# Altium schematic sheet ECU_QGSM2.SchDoc
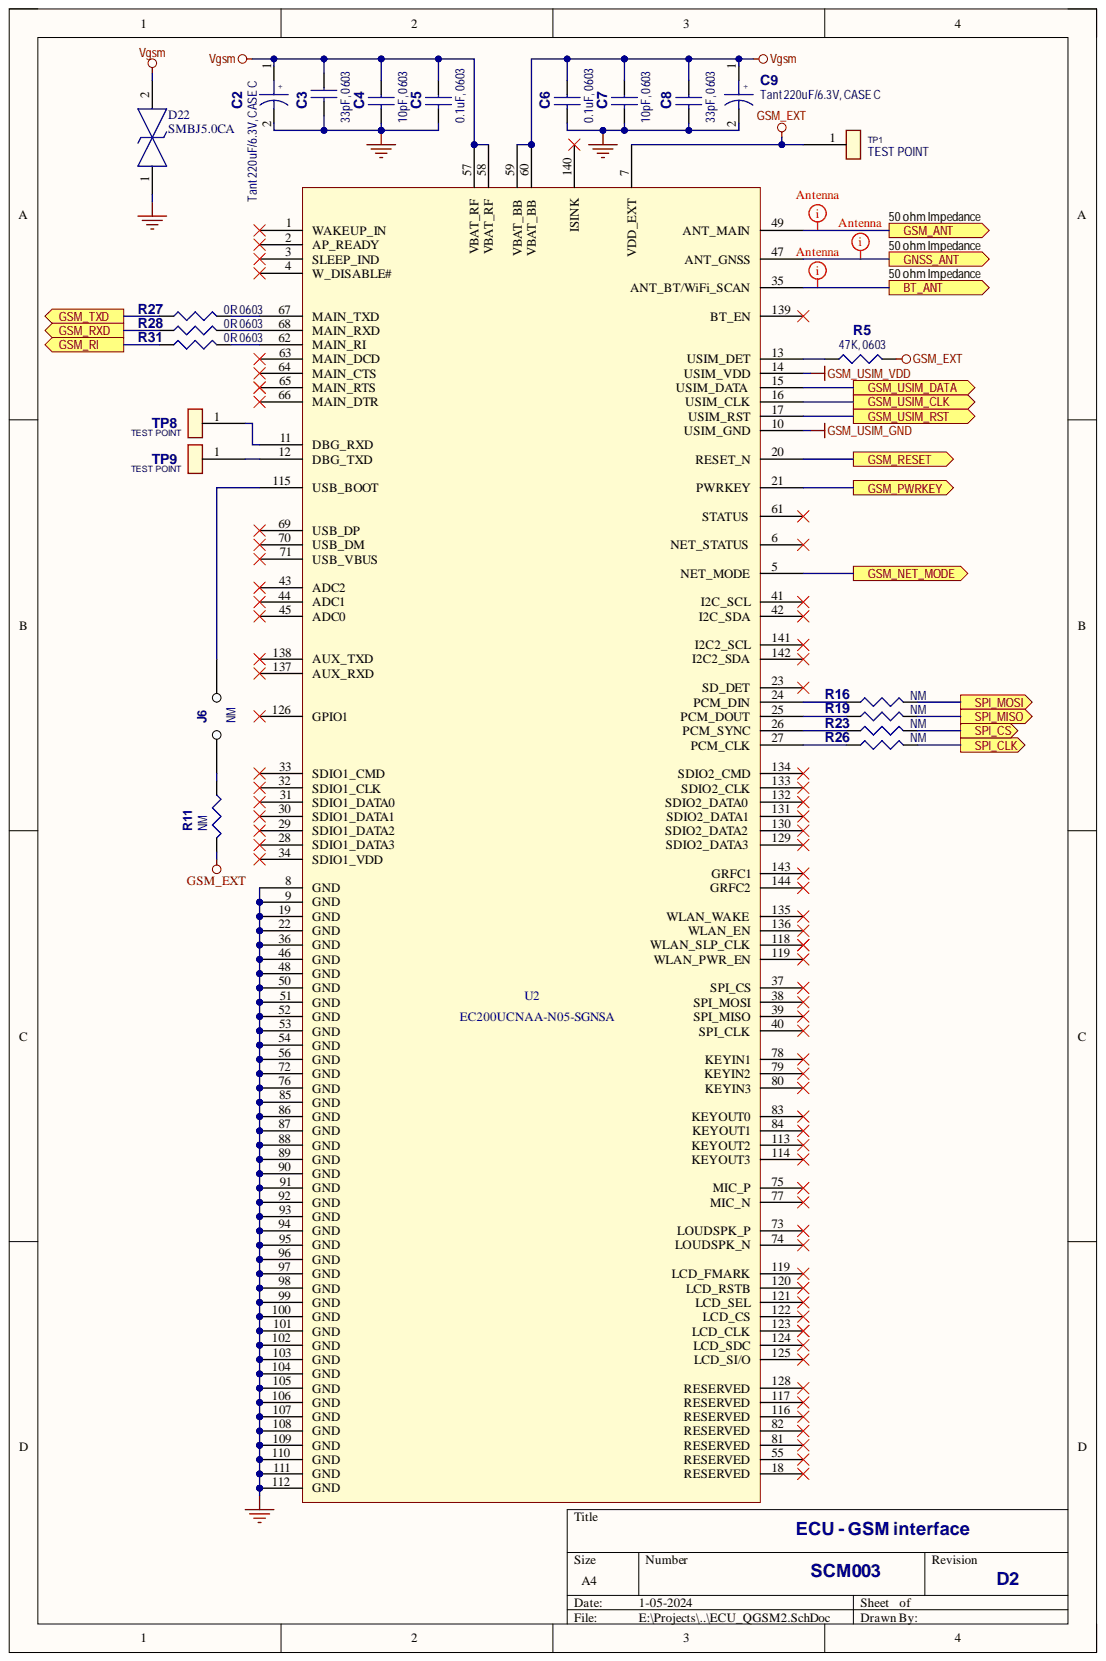
[diagram p0: ECU_QGSM2.SchDoc]

## Parts
C2  Tant 220uF/6.3V, CASE C  [220UF]
C3  33pF, 0603  [C0603]
C4  10pF, 0603  [C0603]
C5  0.1uF, 0603  [C0603]
C6  0.1uF, 0603  [C0603]
C7  10pF, 0603  [C0603]
C8  33pF, 0603  [C0603]
C9  Tant 220uF/6.3V, CASE C  [220UF]
D22  SMBJ5.0CA  [DIONM5436X244N]
J6  NM  [HDR1X2]
R5  47K, 0603  [R0603]
R11  NM  [R0603]
R16  NM  [R0603]
R19  NM  [R0603]
R23  NM  [R0603]
R26  NM  [R0603]
R27  0R 0603  [R0603]
R28  0R 0603  [R0603]
R31  0R 0603  [R0603]
TP1  TEST POINT  [Test Point SMD 1.5mm]
TP8  TEST POINT  [Test Point SMD 1.5mm]
TP9  TEST POINT  [Test Point SMD 1.5mm]
U2  EC200UCNAA-N05-SGNSA  [EC200U]

## Ports
BT_ANT, GNSS_ANT, GSM_ANT, GSM_NET_MODE, GSM_PWRKEY, GSM_RESET, GSM_RI, GSM_RXD, GSM_TXD, GSM_USIM_CLK, GSM_USIM_DATA, GSM_USIM_RST, SPI_CLK, SPI_CS, SPI_MISO, SPI_MOSI

## Nets
GND_POWER: C2.2 C3.2 C4.2 C5.2 C6.2 C7.2 C8.2 C9.2 D22.1 U2.8(GND) U2.9(GND) U2.19(GND) U2.22(GND) U2.36(GND) U2.46(GND) U2.48(GND) U2.50(GND) U2.51(GND) U2.52(GND) U2.53(GND) U2.54(GND) U2.56(GND) U2.72(GND) U2.76(GND) U2.85(GND) U2.86(GND) U2.87(GND) U2.88(GND) U2.89(GND) U2.90(GND) U2.91(GND) U2.92(GND) U2.93(GND) U2.94(GND) U2.95(GND) U2.96(GND) U2.97(GND) U2.98(GND) U2.99(GND) U2.100(GND) U2.101(GND) U2.102(GND) U2.103(GND) U2.104(GND) U2.105(GND) U2.106(GND) U2.107(GND) U2.108(GND) U2.109(GND) U2.110(GND) U2.111(GND) U2.112(GND)
GSM_EXT: R5.2 R11.1 TP1.1 U2.7(VDD_EXT)
GSM_USIM_GND: U2.10(USIM_GND)
GSM_USIM_VDD: U2.14(USIM_VDD)
Vgsm: C2.1 C3.1 C4.1 C5.1 C6.1 C7.1 C8.1 C9.1 D22.2 U2.57(VBAT_RF) U2.58(VBAT_RF) U2.59(VBAT_BB) U2.60(VBAT_BB)
BT_ANT: U2.35(ANT_BT/WiFi_SCAN) ; port BT_ANT
GNSS_ANT: U2.47(ANT_GNSS) ; port GNSS_ANT
GSM_ANT: U2.49(ANT_MAIN) ; port GSM_ANT
GSM_NET_MODE: U2.5(NET_MODE) ; port GSM_NET_MODE
GSM_PWRKEY: U2.21(PWRKEY) ; port GSM_PWRKEY
GSM_RESET: U2.20(RESET_N) ; port GSM_RESET
GSM_RI: R31.1 ; port GSM_RI
GSM_RXD: R28.1 ; port GSM_RXD
GSM_TXD: R27.1 ; port GSM_TXD
GSM_USIM_CLK: U2.16(USIM_CLK) ; port GSM_USIM_CLK
GSM_USIM_DATA: U2.15(USIM_DATA) ; port GSM_USIM_DATA
GSM_USIM_RST: U2.17(USIM_RST) ; port GSM_USIM_RST
SPI_CLK: R26.2 ; port SPI_CLK
SPI_CS: R23.2 ; port SPI_CS
SPI_MISO: R19.2 ; port SPI_MISO
SPI_MOSI: R16.2 ; port SPI_MOSI
NetJ6_1: J6.1 U2.115(USB_BOOT)
NetJ6_2: J6.2 R11.2
NetR5_1: R5.1 U2.13(USIM_DET)
NetR16_1: R16.1 U2.24(PCM_DIN)
NetR19_1: R19.1 U2.25(PCM_DOUT)
NetR23_1: R23.1 U2.26(PCM_SYNC)
NetR26_1: R26.1 U2.27(PCM_CLK)
NetR27_2: R27.2 U2.67(MAIN_TXD)
NetR28_2: R28.2 U2.68(MAIN_RXD)
NetR31_2: R31.2 U2.62(MAIN_RI)
NetTP8_1: TP8.1 U2.11(DBG_RXD)
NetTP9_1: TP9.1 U2.12(DBG_TXD)

Not connected: U2 1(WAKEUP_IN) 2(AP_READY) 3(SLEEP_IND) 4(W_DISABLE#) 6(NET_STATUS) 18(RESERVED) 23(SD_DET) 28(SDIO1_DATA3) 29(SDIO1_DATA2) 30(SDIO1_DATA1) 31(SDIO1_DATA0) 32(SDIO1_CLK) 33(SDIO1_CMD) 34(SDIO1_VDD) 37(SPI_CS) 38(SPI_MOSI) 39(SPI_MISO) 40(SPI_CLK) 41(I2C_SCL) 42(I2C_SDA) 43(ADC2) 44(ADC1) 45(ADC0) 55(RESERVED) 61(STATUS) 63(MAIN_DCD) 64(MAIN_CTS) 65(MAIN_RTS) 66(MAIN_DTR) 69(USB_DP) 70(USB_DM) 71(USB_VBUS) 73(LOUDSPK_P) 74(LOUDSPK_N) 75(MIC_P) 77(MIC_N) 78(KEYIN1) 79(KEYIN2) 80(KEYIN3) 81(RESERVED) 82(RESERVED) 83(KEYOUT0) 84(KEYOUT1) 113(KEYOUT2) 114(KEYOUT3) 116(RESERVED) 117(RESERVED) 118(WLAN_SLP_CLK) 119(LCD_FMARK) 120(LCD_RSTB) 121(LCD_SEL) 122(LCD_CS) 123(LCD_CLK) 124(LCD_SDC) 125(LCD_SI/O) 126(GPIO1) 128(RESERVED) 129(SDIO2_DATA3) 130(SDIO2_DATA2) 131(SDIO2_DATA1) 132(SDIO2_DATA0) 133(SDIO2_CLK) 134(SDIO2_CMD) 135(WLAN_WAKE) 136(WLAN_EN) 137(AUX_RXD) 138(AUX_TXD) 139(BT_EN) 140(ISINK) 141(I2C2_SCL) 142(I2C2_SDA) 143(GRFC1) 144(GRFC2)

## Library data of the parts
C2 C9 = CAPACITOR POL: Component Kind: Standard
C3-C8 = CAP NP_Dup2: Component Kind: Standard
D22 = SMBJ5.0CA: TVS Diode (Bi-directional); Manufacturer_Name: Bourns; Arrow Part Number: SMBJ5.0CA; Arrow Price/Stock: https://www.arrow.com/en/products/smbj5.0ca/bourns?region=nac; Component Kind: Standard; Datasheet Link: https://componentsearchengine.com/Datasheets/2/SMBJ5.0CA.pdf; Height: 2.44mm; Manufacturer_Part_Number: SMBJ5.0CA; Mouser Part Number: 652-SMBJ5.0CA; Mouser Price/Stock: https://www.mouser.co.uk/ProductDetail/Bourns/SMBJ5.0CA?qs=d7fLapxX37G%252BYqtbaPWSkg%3D%3D
J6 = JUMPER: Component Kind: Standard
R5 R16 R19 R23 R26-R28 R31 = R_Dup2: Component Kind: Standard
R11 = R_Dup1: Component Kind: Standard
U2 = EC200U: Component Kind: Standard; Library Name: 4gSchLib.SchLib
TP1 TP8 TP9 = TEST POINT: Component Kind: Standard
